# Altium schematic sheet POWER&USB_USART.SchDoc(POWER&USB_USART)
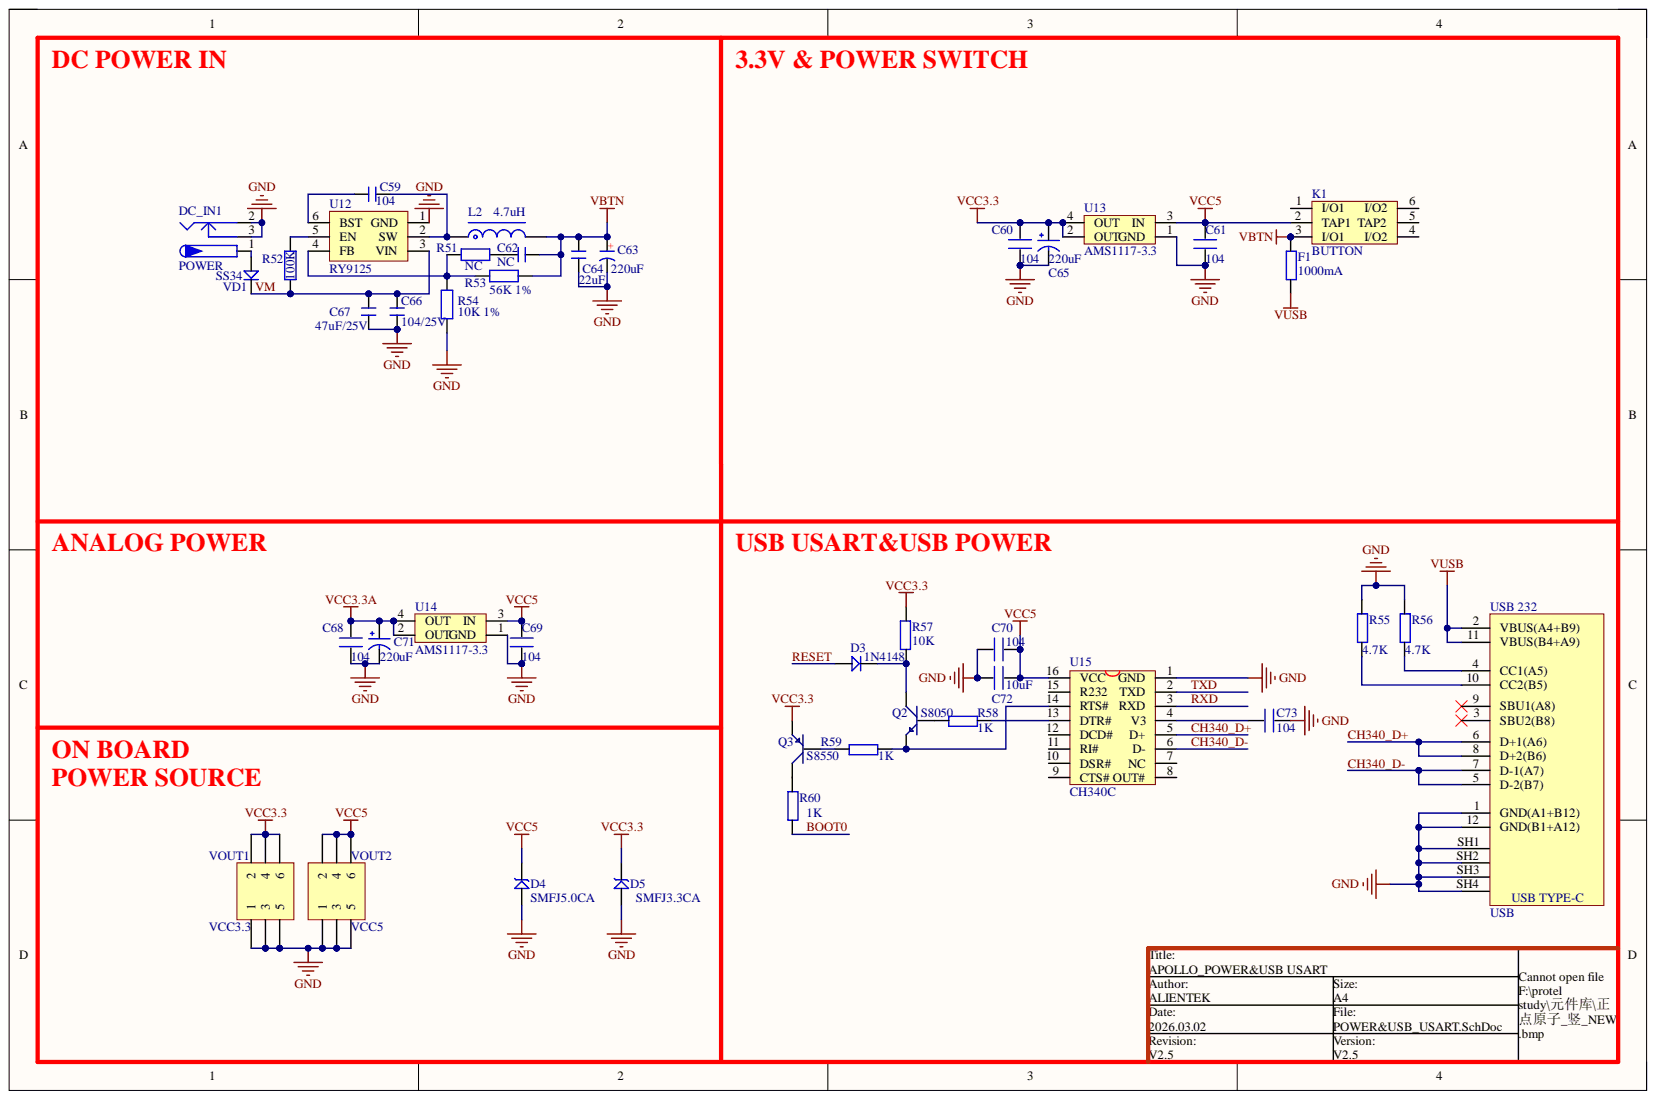
[diagram p0: POWER&USB_USART.SchDoc]

## Parts
C59  104  [0402]
C60  104  [0402]
C61  104  [0402]
C62  NC  [0402]
C63  220uF  [CM D(6.3*5.4)]
C64  22uF  [C 0805]
C65  220uF  [CC6.56.5]
C66  104/25V  [0402]
C67  47uF/25V  [1206]
C68  104  [0402]
C69  104  [0402]
C70  104  [0402]
C71  220uF  [CC6.56.5]
C72  10uF  [0603P]
C73  104  [0402]
D3  1N4148  [DIODE_SOP]
D4  SMFJ5.0CA  [SOD-123]
D5  SMFJ3.3CA  [SOD-123]
DC_IN1  POWER  [DC10B]
F1  1000mA  [0805]
K1  BUTTON  [BTN8.5*8.5]
L2  4.7uH  [L-SMD-0420]
Q2  S8050  [SOT-23R]
Q3  S8550  [SOT-23R]
R51  NC  [0402]
R52  100K  [0402]
R53  56K 1%  [0402]
R54  10K 1%  [0402]
R55  4.7K  [0402]
R56  4.7K  [0402]
R57  10K  [0402]
R58  1K  [0402]
R59  1K  [0402]
R60  1K  [0402]
U12  RY9125  [SOT23-6N]
U13  AMS1117-3.3  [AMS1117]
U14  AMS1117-3.3  [AMS1117]
U15  CH340C  [SOP16M]
USB_232  USB  [USB Type-C]
VD1  SS34  [SMA]
VOUT1  VCC3.3  [HDR2X3]
VOUT2  VCC5  [HDR2X3]

## Nets
GND: C60.1 C61.1 C63.2 C64.2 C65.2 C66.1 C67.1 C68.1 C69.1 C70.1 C71.2 C72.2 C73.2 D4.1 D5.1 DC_IN1.2 DC_IN1.3 R54.2 R55.2 R56.2 U12.1(GND) U13.1(GND) U14.1(GND) U15.1(GND) USB_232.1(GND(A1+B12)) USB_232.12(GND(B1+A12)) USB_232.SH1(Shield1) USB_232.SH2(Shield2) USB_232.SH3(Shield3) USB_232.SH4(Shield4) VOUT1.1 VOUT1.3 VOUT1.5 VOUT2.1 VOUT2.3 VOUT2.5
VBTN: C62.2 C63.1 C64.1 F1.2 K1.3(I/O1) L2.2 R53.2
VCC3.3: C60.2 C65.1 D5.2 Q3.1 R57.1 U13.2(OUT) U13.4(OUT) VOUT1.2 VOUT1.4 VOUT1.6
VCC3.3A: C68.2 C71.1 U14.2(OUT) U14.4(OUT)
VCC5: C61.2 C69.2 C70.2 C72.1 D4.2 K1.2(TAP1) U13.3(IN) U14.3(IN) U15.16(VCC) VOUT2.2 VOUT2.4 VOUT2.6
VUSB: F1.1 USB_232.2(VBUS(A4+B9)) USB_232.11(VBUS(B4+A9))
BOOT0: R60.1
CH340_D+: U15.5(D+) USB_232.6(D+1(A6)) USB_232.8(D+2(B6))
CH340_D-: U15.6(D-) USB_232.5(D-2(B7)) USB_232.7(D-1(A7))
RESET: D3.1
RXD: U15.3(RXD)
TXD: U15.2(TXD)
VM: C66.2 C67.2 R52.2 U12.3(VIN) VD1.2
NetC59_1: C59.1 U12.6(BST)
NetC59_2: C59.2 L2.1 U12.2(SW)
NetC62_1: C62.1 R51.2
NetC73_1: C73.1 U15.4(V3)
NetD3_2: D3.2 Q2.3 R57.2
NetDC_IN1_1: DC_IN1.1 VD1.1
NetQ2_1: Q2.1 R59.2 U15.14(RTS#)
NetQ2_2: Q2.2 R58.1
NetQ3_2: Q3.2 R59.1
NetQ3_3: Q3.3 R60.2
NetR51_1: R51.1 R53.1 R54.1 U12.4(FB)
NetR52_1: R52.1 U12.5(EN)
NetR55_1: R55.1 USB_232.10(CC2(B5))
NetR56_1: R56.1 USB_232.4(CC1(A5))
NetR58_2: R58.2 U15.13(DTR#)

Not connected: K1 1(I/O1) 4(I/O2) 5(TAP2) 6(I/O2); U15 7(NC) 8(OUT#) 9(CTS#) 10(DSR#) 11(RI#) 12(DCD#) 15(R232); USB_232 3(SBU2(B8)) 9(SBU1(A8))

## Library data of the parts
C59 C62 C64 C66 C67 = C: 无极性贴片电容; 版权归属: 源创客; BOM名称: 无极性贴片电容; Component Kind: Standard; Library Name: 电阻-电容-电感.SchLib; 学习资源: www.ooocke.com
C60 C61 C68-C70 C72 C73 = C: Component Kind: Standard; Library Name: MYCOMLIB.IntLib
C63 = C-CM: 贴片电解电容; 版权归属: 源创客; BOM名称: 贴片电解电容; Component Kind: Standard; Library Name: 电阻-电容-电感.SchLib; 学习资源: www.ooocke.com
C65 C71 = CAP: Component Kind: Standard; Library Name: MYCOMLIB.IntLib
D3 = D: Component Kind: Standard; Description: 1N4148; Library Name: MYCOMLIB.IntLib
D4 D5 = SMBJ: TVS; Component Kind: Standard; Library Name: ALIENTEK_COMPONENT.IntLib
DC_IN1 = P-DC: 低压电源接口; BOM名称: DC电源接口; 版权归属: 源创客; Component Kind: Standard; Library Name: 接口类.SchLib; 学习资源: www.ooocke.com
F1 R55-R60 = R: Component Kind: Standard
  F1 R55 R56 R59 R60: Library Name: MYCOMLIB.IntLib
  R57 R58: Library Name: ALIENTEK_COMPONENT.IntLib
L2 = L-CDRH: 功率屏蔽电感; 版权归属: 源创客; BOM名称: 功率屏蔽电感; Component Kind: Standard; Library Name: 电阻-电容-电感.SchLib; 学习资源: www.ooocke.com
Q2 = NPN: 8050/BCW846/BCW847; Component Kind: Standard; Library Name: ALIENTEK_COMPONENT.IntLib
Q3 = PNP: 8550/BCW68; Component Kind: Standard; Library Name: MYCOMLIB.IntLib
R51-R54 = R: 贴片电阻; 版权归属: 源创客; BOM名称: 贴片电阻; Component Kind: Standard; Library Name: 电阻-电容-电感.SchLib; 学习资源: www.ooocke.com
U12 = DC JW5060T_6: DC降压芯片; Component Kind: Standard; Library Name: LDO和DCDC.SchLib
U13 U14 = AMS1117: Component Kind: Standard; Library Name: MYCOMLIB.IntLib
U15 = CH340C: USB2UART; Component Kind: Standard; Library Name: ALIENTEK_COMPONENT.IntLib
USB_232 = USB Type-C: 12P TypeC 母座; Component Kind: Standard; Library Name: 接口类.SchLib
VD1 = SS14: 肖特基二极管; 属性: 40V/1A; 版权归属: 源创客; BOM名称: 肖特基二极管; Component Kind: Standard; Library Name: 二极管-整流桥.SchLib; 学习资源: www.ooocke.com
VOUT1 VOUT2 = Header 3X2: Header, 3-Pin, Dual row; Component Kind: Standard; LatestRevisionDate: 17-Jul-2002; LatestRevisionNote: Re-released for DXP Platform.; Library Name: Miscellaneous Connectors.IntLib; Publisher: Altium Limited
K1 = BUTTON: Component Kind: Standard; Library Name: ALIENTEK_COMPONENT.IntLib
